# Altium schematic sheet POWER.SchDoc
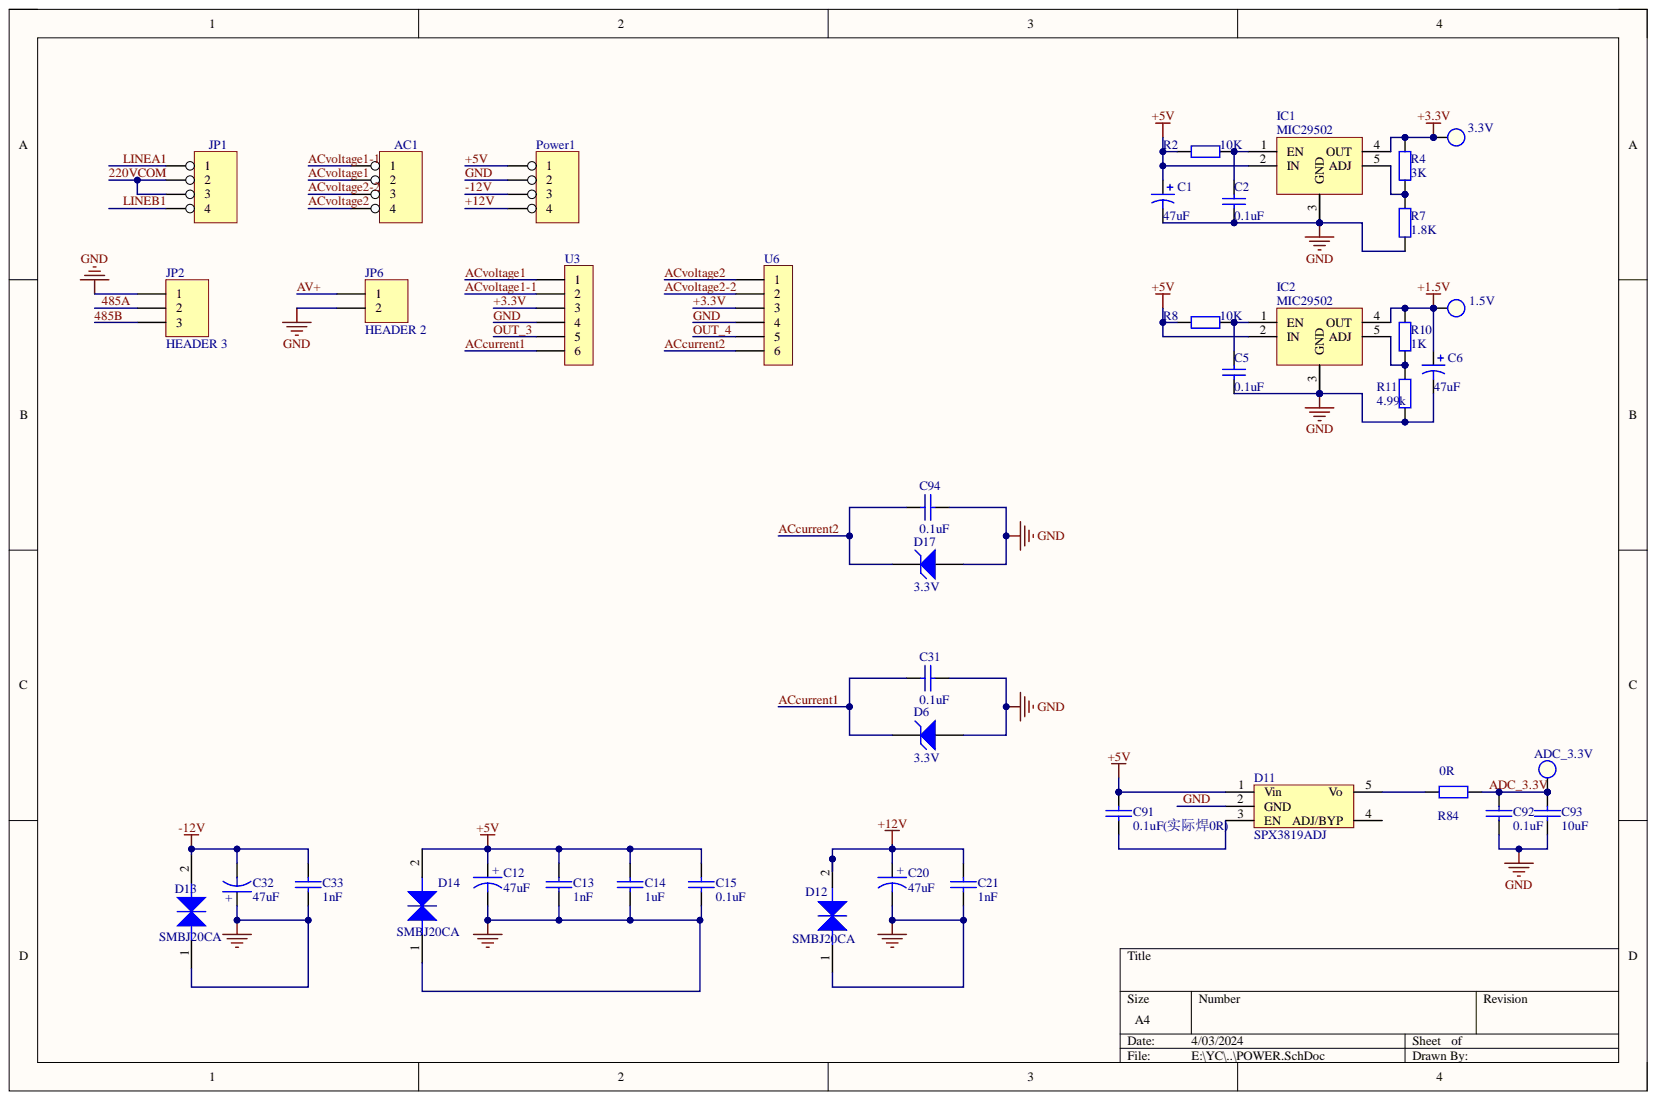
[diagram p0: POWER.SchDoc]

## Parts
1.5V  TERM  [TERM]  (value not drawn)
3.3V  TERM  [TERM]  (value not drawn)
AC1  4 HEADER  [TERM-3.0-4]  (value not drawn)
ADC_3.3V  TERM  [TERM]  (value not drawn)
C1  47uF  [SMD-D]
C2  0.1uF  [0805C]
C5  0.1uF  [0805C]
C6  47uF  [SMD-D]
C12  47uF  [SMD-D]
C13  1nF  [0805C]
C14  1uF  [0805C]
C15  0.1uF  [0805C]
C20  47uF  [SMD-D]
C21  1nF  [0805C]
C31  0.1uF  [0805C]
C32  47uF  [SMD-D]
C33  1nF  [0805C]
C91  0.1uF(实际焊0R)  [0805C]
C92  0.1uF  [0805C]
C93  10uF  [0805C]
C94  0.1uF  [0805C]
D6  3.3V  [1206D]
D11  SPX3819ADJ  [SOT23-5]
D12  SMBJ22CA  [SMB]  (value not drawn)
D13  SMBJ22CA  [SMB]  (value not drawn)
D14  SMBJ22CA  [SMB]  (value not drawn)
D17  3.3V  [1206D]
IC1  MIC29502  [TO-263-5]
IC2  MIC29502  [TO-263-5]
JP1  4 HEADER  [TERM-3.0-4]  (value not drawn)
JP2  HEADER 3  [7.62con]
JP6  HEADER 2  [TERM-3.0-2]
Power1  4 HEADER  [TERM-3.0-4]  (value not drawn)
R2  10K  [0805]
R4  3K  [0805]
R7  1.8K  [0805]
R8  10K  [0805]
R10  value 1K  [0805]  (hidden comment: 3K)
R11  value 4.99k  [0805]  (hidden comment: 3K)
R84  0R  [0805]
U3  U3  [Module_ACS758]
U6  U6  [Module_ACS758]

## Nets
+1.5V: 1.5V.1 C6.1 IC2.4(OUT) R10.2
220VCOM: JP1.2 JP1.3
485A: JP2.2
485B: JP2.3
+3.3V: 3.3V.1 IC1.4(OUT) R4.2 U3.3 U6.3
+5V: C1.1 C12.1 C13.1 C14.1 C15.1 C91.1 D11.1(Vin) D14.2 IC1.2(IN) IC2.2(IN) Power1.1 R2.1 R8.1
+12V: C20.1 C21.1 D12.2 Power1.4
-12V: C32.2 C33.1 D13.2 Power1.3
ACcurrent1: C31.1 D6.2 U3.6
ACcurrent2: C94.1 D17.2 U6.6
ACvoltage1: AC1.2 U3.1
ACvoltage1-1: AC1.1 U3.2
ACvoltage2: AC1.4 U6.1
ACvoltage2-2: AC1.3 U6.2
ADC_3.3V: ADC_3.3V.1 C92.1 C93.1 R84.2
AV+: JP6.1
GND: C1.2 C2.1 C5.1 C6.2 C12.2 C13.2 C14.2 C15.2 C20.2 C21.2 C31.2 C32.1 C33.2 C92.2 C93.2 C94.2 D6.1 D11.2(GND) D12.1 D13.1 D14.1 D17.1 IC1.3(GND) IC1.6 IC2.3(GND) IC2.6 JP2.1 JP6.2 Power1.2 R7.1 R11.1 U3.4 U6.4
LINEA1: JP1.1
LINEB1: JP1.4
OUT_3: U3.5
OUT_4: U6.5
NetC2_2: C2.2 IC1.1(EN) R2.2
NetC5_2: C5.2 IC2.1(EN) R8.2
NetC91_2: C91.2 D11.3(EN)
NetD11_5: D11.5(Vo) R84.1
NetIC1_5: IC1.5(ADJ) R4.1 R7.2
NetIC2_5: IC2.5(ADJ) R10.1 R11.2

Not connected: D11 4(ADJ/BYP)

## Library data of the parts
AC1 JP1 Power1 = 4 HEADER: 4 Pin Header; Component Kind: Standard; Library Name: 杂元件库.SCHLIB
C1 C6 = Cap Pol1: Polarized Capacitor (Radial); Component Kind: Standard; LatestRevisionDate: 17-Jul-2002; LatestRevisionNote: Re-released for DXP Platform.; Library Name: Miscellaneous Devices.IntLib; PackageDescription: Polarized Capacitor (Radial); 2 Leads; PackageReference: RB7.6-15; Published: 8-Jun-2000; Signal Integrity: Cap Pol; Simulation: CAP; Value: 47uF; Publisher: Altium Limited
C2 C5 = Cap: Capacitor; Component Kind: Standard; LatestRevisionDate: 17-Jul-2002; LatestRevisionNote: Re-released for DXP Platform.; Library Name: Miscellaneous Devices.IntLib; PackageDescription: Radial Cap, Thru-Hole; 2 Leads; 0.3 in Pin Spacing; PackageReference: RAD-0.3; Published: 8-Jun-2000; Signal Integrity: Cap; Simulation: CAP; Value: 0.1uF; Publisher: Altium Limited
C12 C20 C32 = CAPACITOR POL: Capacitor; Component Kind: Standard
C13-C15 C21 C31 C33 C94 = CAP: Capacitor; Component Kind: Standard
  C31 C94: Library Name: 3-4.SCHLIB; Value: 0.1uF
C91 C92 = CAP_0603: Capacitor; Component Kind: Standard; Library Name: TR_SCHLIB.SchLib
C93 = CAP_1206: Capacitor; Component Kind: Standard; Library Name: TR_SCHLIB.SchLib
D6 D17 = D Zener: Zener Diode; Component Kind: Standard; LatestRevisionDate: 17-Jul-2002; LatestRevisionNote: Re-released for DXP Platform.; Library Name: Miscellaneous Devices.IntLib; PackageDescription: Diode, Thru-Hole; 2 Leads; 0.7 in Pin Spacing; PackageReference: DIODE-0.7; Published: 8-Jun-2000; Signal Integrity: D Zener; Simulation: ZENER; Publisher: Altium Limited
D11 = SPX3819: LDO; Component Kind: Standard; Library Name: TR_SCHLIB.SchLib
D12-D14 = SMBJ22CA: TVS; : SMBJ20CA; Component Kind: Standard; Library Name: TR_SCHLIB.SchLib
JP2 = HEADER 3: 排针/排母; Component Kind: Standard; Library Name: TR_SCHLIB.SchLib
JP6 = HEADER 2: 排针/排母; Component Kind: Standard; Library Name: TR_SCHLIB.SchLib
R2 R4 R7 R8 R10 R11 = Res2: Resistor; Component Kind: Standard; LatestRevisionDate: 17-Jul-2002; LatestRevisionNote: Re-released for DXP Platform.; Library Name: Miscellaneous Devices.IntLib; PackageDescription: Axial Device, Thru-Hole; 2 Leads; 0.4 in Pin Spacing; PackageReference: AXIAL-0.4; Published: 8-Jun-2000; Signal Integrity: Res; Simulation: RESISTOR; Publisher: Altium Limited
  R2 R8: Value: 10K
  R4: Value: 3K
  R7: Value: 1.8K
R84 = RES: 通用; Component Kind: Standard; Library Name: TR_SCHLIB.SchLib
U3 U6 = CON6: Connector; Component Kind: Standard; Library Name: Backup of 99SE.Lib
1.5V 3.3V ADC_3.3V = TERM: Component Kind: Standard
  1.5V 3.3V: Library Name: Schlib (2).SchLib
  ADC_3.3V: Library Name: TR_SCHLIB.SchLib
IC1 IC2 = MIC29502: Component Kind: Standard; Library Name: Schlib (2).SchLib
